# Altium schematic sheet SCH007 - SFP_10GbE.SchDoc
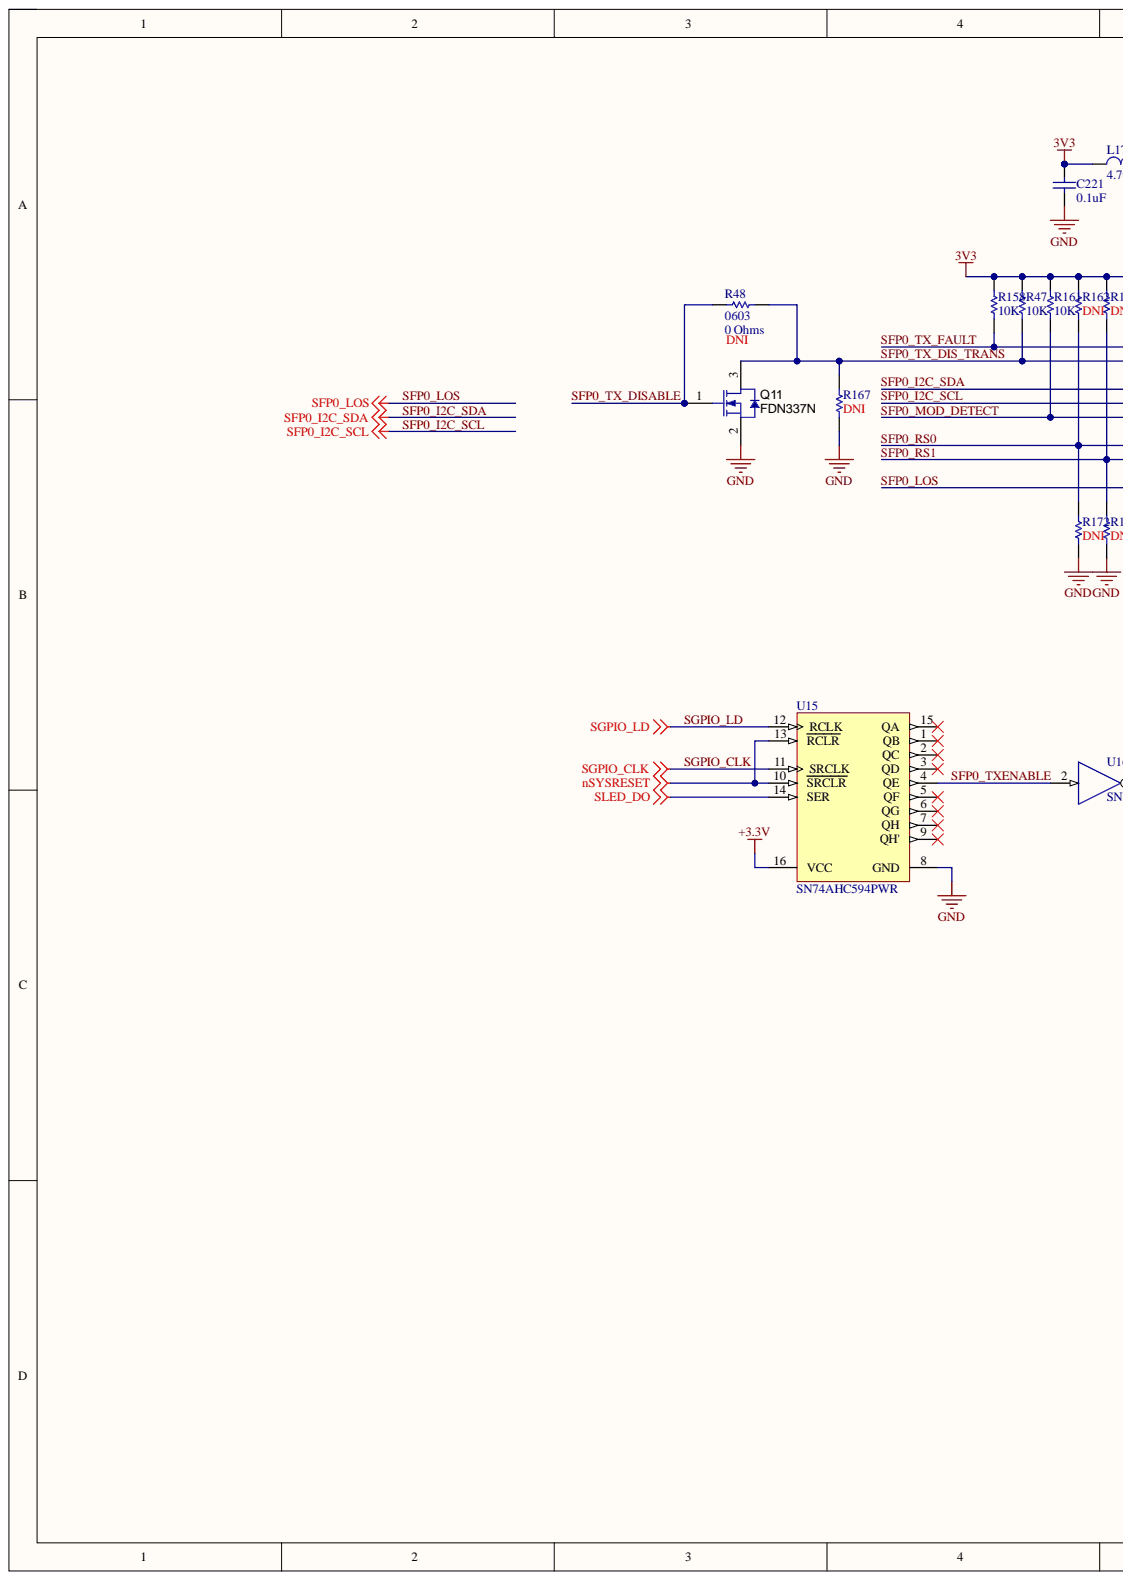
[diagram p0: SCH007 - SFP_10GbE.SchDoc, left]
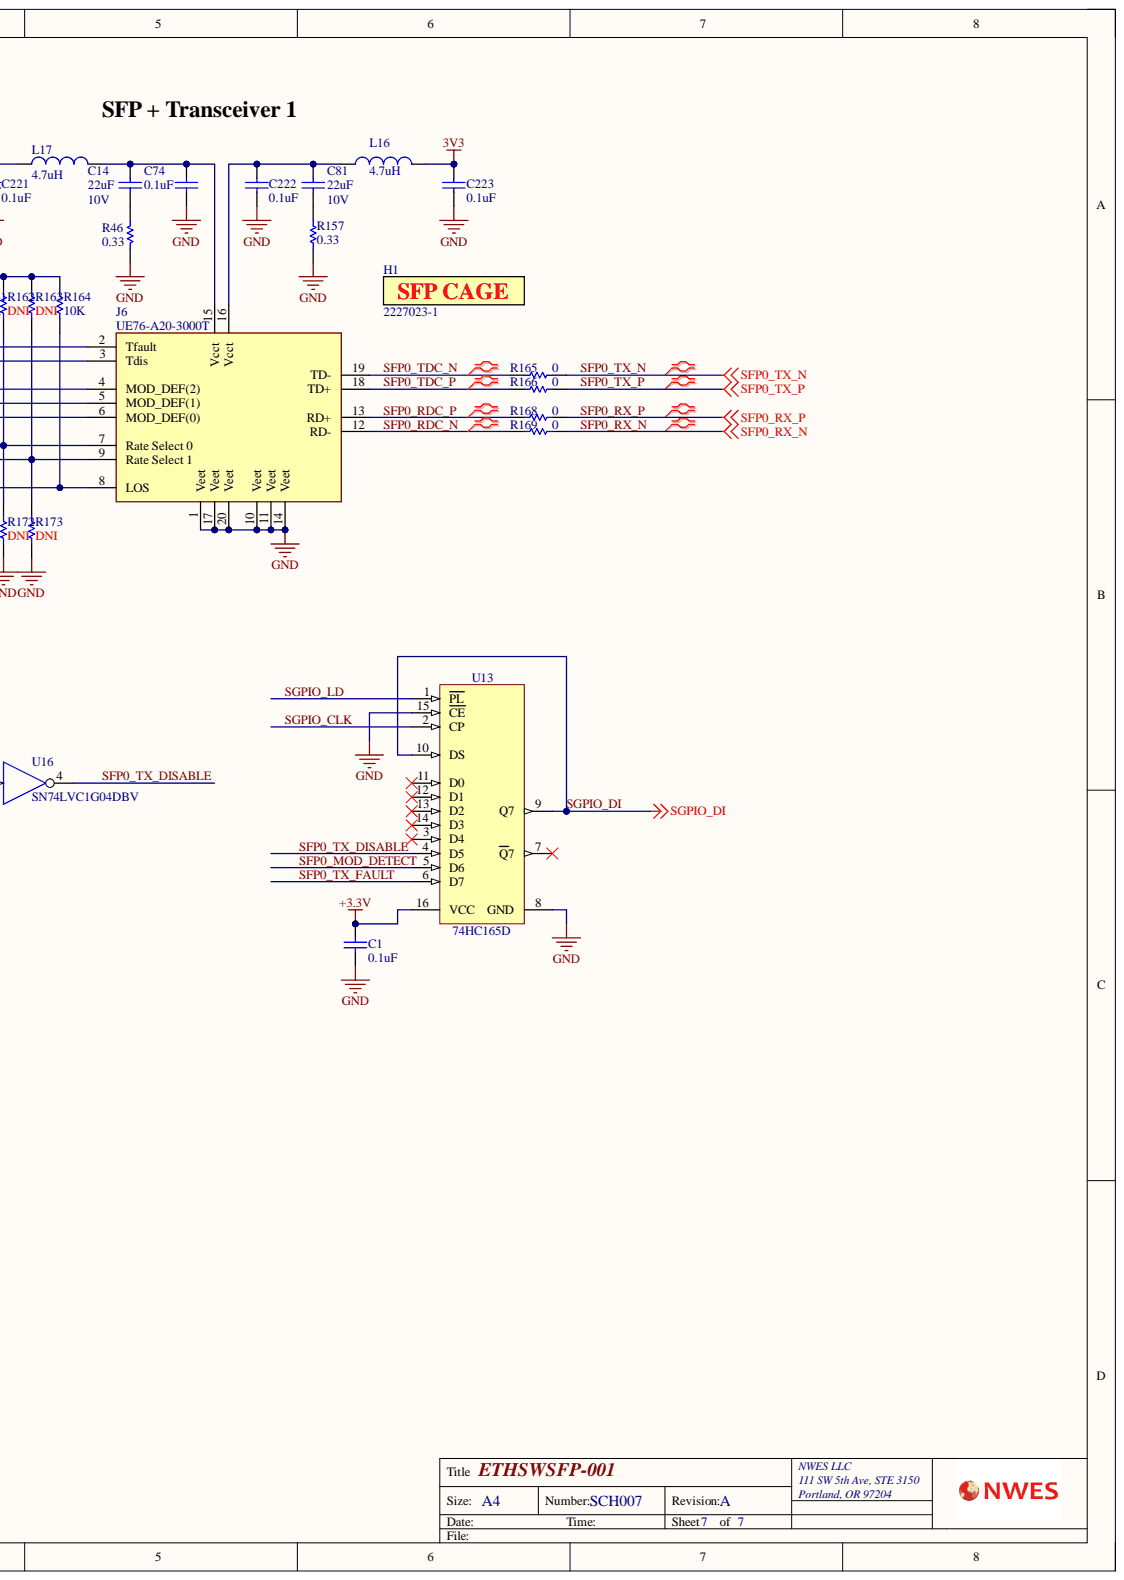
[diagram p1: SCH007 - SFP_10GbE.SchDoc, right]

## Parts
C1  0.1uF  [CAPC1005X55USR]
C14  22uF  Voltage Rating: 10V  [CAP_0603]
C74  0.1uF  [CAPC1005X55USR]
C81  22uF  Voltage Rating: 10V  [CAP_0603]
C221  0.1uF  [CAPC1005X55USR]
C222  0.1uF  [CAPC1005X55USR]
C223  0.1uF  [CAPC1005X55USR]
H1  2227023-1  Connector Type: SFP  [TE_2227023-1]
J6  UE76-A20-3000T  [AMPHENOL_UE76-A20-3000T]
L16  4.7uH  [INDC2520X120N]
L17  4.7uH  [INDC2520X120N]
Q11  FDN337N  [SOT-23]
R46  0.33  [RESC1608N]
R47  10K  [RESC1005X40USR]
R48  DNI  value 0 Ohms  Case Code (Imperial): 0603  [RESC1608_IS0603N]
R157  0.33  [RESC1608N]
R158  10K  [RESC1005X40USR]
R161  10K  [RESC1005X40USR]
R162  DNI  value 10K  [RESC1005X40USR]
R163  DNI  [RESC1005X40USR]
R164  10K  [RESC1005X40USR]
R165  0  [RESC1005X40USR]
R166  0  [RESC1005X40USR]
R167  DNI  [RESC1005X40USR]
R168  0  [RESC1005X40USR]
R169  0  [RESC1005X40USR]
R172  DNI  [RESC1005X40USR]  (no BOM)
R173  DNI  [RESC1005X40USR]  (no BOM)
U13  74HC165D  [SOIC127P600X175-16N]
U15  SN74AHC594PWR  [PW0016A_M]
U16  SN74LVC1G04DBV  [SOT95P280X145-5N]

## Nets
3V3: C221.2 C223.2 L16.2 L17.2 R47.2 R158.2 R161.2 R162.2 R163.2 R164.2
+3.3V: C1.2 U13.16(VCC) U15.16(VCC)
GND: C1.1 C74.1 C221.1 C222.1 C223.1 J6.1(Veet) J6.10(Veet) J6.11(Veet) J6.14(Veet) J6.17(Veet) J6.20(Veet) Q11.2 R46.1 R157.1 R167.1 R172.1 R173.1 U13.8(GND) U13.15(CE) U15.8(GND) U16.3
nSYSRESET: U15.10(SRCLR) U15.13(RCLR)
SLED_DO: U15.14(SER)
VDD: U16.5
SFP0_I2C_SCL: J6.5(MOD_DEF(1))
SFP0_I2C_SDA: J6.4(MOD_DEF(2))
SFP0_LOS: J6.8(LOS) R164.1
SFP0_MOD_DETECT: J6.6(MOD_DEF(0)) R161.1 U13.5(D6)
SFP0_RDC_N: J6.12(RD-) R169.1
SFP0_RDC_P: J6.13(RD+) R168.1
SFP0_RS0: J6.7(Rate_Select_0) R162.1 R172.2
SFP0_RS1: J6.9(Rate_Select_1) R163.1 R173.2
SFP0_RX_N: R169.2
SFP0_RX_P: R168.2
SFP0_TDC_N: J6.19(TD-) R165.1
SFP0_TDC_P: J6.18(TD+) R166.1
SFP0_TX_DIS_TRANS: J6.3(Tdis) Q11.3 R47.1 R48.2 R167.2
SFP0_TX_DISABLE: Q11.1 R48.1 U13.4(D5) U16.4
SFP0_TX_FAULT: J6.2(Tfault) R158.1 U13.6(D7)
SFP0_TX_N: R165.2
SFP0_TX_P: R166.2
SFP0_TXENABLE: U15.4(QE) U16.2
SGPIO_CLK: U13.2(CP) U15.11(SRCLK)
SGPIO_DI: U13.9(Q7) U13.10(DS)
SGPIO_LD: U13.1(PL) U15.12(RCLK)
NetC14_1: C14.1 C74.2 J6.15(Vcct) L17.1
NetC14_2: C14.2 R46.2
NetC81_1: C81.1 C222.2 J6.16(Vcct) L16.1
NetC81_2: C81.2 R157.2

Not connected: U13 3(D4) 7(Q7) 11(D0) 12(D1) 13(D2) 14(D3); U15 1(QB) 2(QC) 3(QD) 5(QF) 6(QG) 7(QH) 9(QH') 15(QA)

## Library data of the parts
C1 C74 C221-C223 = CAP CER 0.1UF 25V X5R 0402: Manufacturer: Samsung Electro-Mechanics; Manufacturer Part Number: CL05A104KA5NNNC; Component Kind: Standard; Description: CAP CER 0.1UF 25V X5R 0402; Height: 0.55mm; Package: 0402; Supplier 1: Digi-Key; Supplier Part Number 1: 1276-1043-1-ND; value: 0.1uF; Library Name: Radar FPGA RF.SCHLIB
C14 C81 = CAP CER 22UF 10V X5R 0603: Multilayer Ceramic Capacitor, 22 uF, 10 V, ± 20%, X5R, 0603 [1608 Metric]; Manufacturer: TDK; Manufacturer Part Number: C1608X5R1A226M080AC; Capacitance: 22uF; Case Code (Imperial): 0603; Case Code (Metric): 1608; Case/Package: 0603; Category: Ceramic Capacitors; Component Kind: Standard; ComponentLink1Description: https://datasheet.ciiva.com/6274/tdkmlcccaprange-6274860.pdf?src-supplier=Digi-Key; ComponentLink1URL: https://datasheet.ciiva.com/6274/tdkmlcccaprange-6274860.pdf?src-supplier=Digi-Key; ComponentLink2Description: https://datasheet.ciiva.com/6274/tdkmlcccaprange-6274859.pdf?src-supplier=Digi-Key; ComponentLink2URL: https://datasheet.ciiva.com/6274/tdkmlcccaprange-6274859.pdf?src-supplier=Digi-Key; ComponentLink3Description: https://datasheet.ciiva.com/6274/tdkmlcccaprange-6274861.pdf?src-supplier=Digi-Key; ComponentLink3URL: https://datasheet.ciiva.com/6274/tdkmlcccaprange-6274861.pdf?src-supplier=Digi-Key; ComponentLink4Description: https://datasheet.ciiva.com/24216/mlcc-commercial-general-en-24216575.pdf?src-supplier=Mouser; ComponentLink4URL: https://datasheet.ciiva.com/24216/mlcc-commercial-general-en-24216575.pdf?src-supplier=Mouser; Depth: 0.8mm; Dielectric: X5R; Dielectric Material: Ceramic; Height: 0.8mm; Lead Free: Lead Free; Length: 1.6mm; Max Operating Temperature: 85°C; Min Operating Temperature: -55°C; Mount: Surface Mount; Package Quantity: 4000; Packaging: Tape and Reel; Pricing 1: 1=0.45000, 10=0.31300, 50=0.21920, 100=0.18790, 500=0.14090, 1000=0.11898 (USD); Reach SVHC Compliant: Yes; Resistor Type: Ceramic; RoHS: Yes; RoHS Compliant: Yes; Series: C; Stock 1: 4100481; Supplier 1: Digi-Key; Supplier Part Number 1: 445-9077-1-ND; Termination: SMD/SMT; Thickness: 0.035inch; Tolerance: 20%; Voltage Rating (DC): 10V; Weight: 7.1E-05oz; Width: 0.8mm; Library Name: Radar FPGA RF.SCHLIB
H1 = TE_2227023-1: Connector Accessories 1 Port SFP Cage Press Fit Right Angle Through Hole Nickel Tray; Manufacturer: TE Connectivity AMP; Data Rate: 4Gbps; Number of Ports: 1; PCB Thickness: 0.059inch; Tail Length: 3mm; Category: Connectors; Component Kind: Standard; ComponentLink1Description: https://datasheet.ciiva.com/45236/eng-cd-2227023-1-45236427.pdf?src-supplier=Avnet; ComponentLink1URL: https://datasheet.ciiva.com/45236/eng-cd-2227023-1-45236427.pdf?src-supplier=Avnet; ELV: Compliant; Features: Shielded; Library Name: XEM8320.SchLib; Manufacturer Part Number: 2227023-1; Mounting Technology: Through Hole; Number of Positions: 1; Packaging: Tray; Pricing: 1=2.23000, 24=1.98875, 48=1.97854, 96=1.95823, 240=1.79675, 456=1.78667, 960=1.62516, 2400=1.45356 (USD); RoHS: Yes; RoHS Compliant: Yes; Series: -; Stock: 3865; Supplier 1: Digi-Key; Supplier Part Number 1: A120615-ND; Termination: Press-Fit
J6 = UE76-A20-3000T: Conn SFP+ RCP 20 POS 0.8mm Solder RA SMD 20 Terminal 1 Port T/R; Manufacturer: Amphenol Communications Solutions; Component Kind: Standard; Manufacturer Part Number: UE76-A20-3000T; Pricing 1: 500=0.81500, 1000=0.79500, 1500=0.78000, 2000=0.77500, 2500=0.75000 (USD); RoHS: No; Stock 1: 500; Supplier 1: Future Electronics; Supplier Part Number 1: 9135124; Library Name: Radar FPGA RF.SCHLIB
L16 L17 = FIXED IND 4.7UH 2A 200 MOHM SMD: Manufacturer: Murata; Manufacturer Part Number: DFE252012P-4R7M=P2; Core Material: Ferrite; DC Resistance (DCR): 200mR; Max DC Current: 1.4A; Shielding: Shielded; Test Frequency: 1MHz; Tolerance: 20%; Case Code (Imperial): 1008; Case Code (Metric): 2520; Case/Package: 1008; Category: Fixed Inductors; Component Kind: Standard; ComponentLink1Description: http://www.farnell.com/datasheets/2550138.pdf?src-supplier=Farnell; ComponentLink1URL: http://www.farnell.com/datasheets/2550138.pdf?src-supplier=Farnell; ComponentLink2Description: http://www.toko.co.jp/products/pdf/inductors/dfe252012p.pdf?src-supplier=Avnet; ComponentLink2URL: http://www.toko.co.jp/products/pdf/inductors/dfe252012p.pdf?src-supplier=Avnet; ComponentLink3Description: http://www.farnell.com/datasheets/2550138.pdf?src-supplier=Newark; ComponentLink3URL: http://www.farnell.com/datasheets/2550138.pdf?src-supplier=Newark; ComponentLink4Description: http://www.murata.com/~/media/webrenewal/products/inductor/chip/tokoproducts/wirewoundmetalalloychiptype/m_dfe252012p.ashx?src-supplier=Digi-Key; ComponentLink4URL: http://www.murata.com/~/media/webrenewal/products/inductor/chip/tokoproducts/wirewoundmetalalloychiptype/m_dfe252012p.ashx?src-supplier=Digi-Key; ComponentLink5Description: https://www.murata.com/~/media/webrenewal/products/inductor/chip/tokoproducts/wirewoundmetalalloychiptype/m_dfe252012p.ashx?src-supplier=Digi-Key; ComponentLink5URL: https://www.murata.com/~/media/webrenewal/products/inductor/chip/tokoproducts/wirewoundmetalalloychiptype/m_dfe252012p.ashx?src-supplier=Digi-Key; Current Rating: 2A; Depth: 2mm; Description: FIXED IND 4.7UH 2A 200 MOHM SMD; Height - Seated (Max): 0.047inch; Inductance: 4.7uH; Length: 0.098inch; Max Operating Temperature: 125°C; Min Operating Temperature: -40°C; Mount: Surface Mount; Packaging: Tape and Reel; Pricing 1: 1=0.31000, 10=0.27500, 25=0.24040, 50=0.20600, 100=0.18890, 250=0.17168, 500=0.16140, 1000=0.12362 (USD); Resistor Type: Wirewound,Metal Alloy; RoHS: Yes; RoHS Compliant: Yes; Stock 1: 151905; Supplier 1: Digi-Key; Supplier Part Number 1: 490-10651-1-ND; Width: 0.079inch; Library Name: Radar FPGA RF.SCHLIB
Q11 = MOSFET N-CH 30V 2.2A SUPERSOT3: MOSFET N-CH 30V 2.2A SSOT3; Manufacturer: ON Semiconductor / Fairchild; Drain to Source Resistance: 65mR; Element Configuration: Single; Fall Time: 10ns; Gate to Source Voltage (Vgs): 8V; Input Capacitance: 300pF; Max Power Dissipation: 500mW; Nominal Vgs: 700mV; Number of Elements: 1; Polarity: N-CHANNEL; Turn-Off Delay Time: 17ns; Turn-On Delay Time: 4ns; Case/Package: TO-236-3; Category: MOSFETs; Component Kind: Standard; ComponentLink1Description: https://datasheet.ciiva.com/14092/fdn337n-eng-datasheet-14092051.pdf?src-supplier=Distrelec; ComponentLink1URL: https://datasheet.ciiva.com/14092/fdn337n-eng-datasheet-14092051.pdf?src-supplier=Distrelec; ComponentLink2Description: https://datasheet.ciiva.com/4442/fdn337n-44286-4442315.pdf?src-supplier=Mouser; ComponentLink2URL: https://datasheet.ciiva.com/4442/fdn337n-44286-4442315.pdf?src-supplier=Mouser; ComponentLink3Description: https://datasheet.ciiva.com/19470/871017-19470804.pdf?src-supplier=Jameco; ComponentLink3URL: https://datasheet.ciiva.com/19470/871017-19470804.pdf?src-supplier=Jameco; ComponentLink4Description: https://datasheet.ciiva.com/6037/fdn337n-6037720.pdf?src-supplier=Farnell; ComponentLink4URL: https://datasheet.ciiva.com/6037/fdn337n-6037720.pdf?src-supplier=Farnell; ComponentLink5Description: https://datasheet.ciiva.com/22681/220571-22681884.pdf?src-supplier=Element14; ComponentLink5URL: https://datasheet.ciiva.com/22681/220571-22681884.pdf?src-supplier=Element14; ComponentLink6Description: https://datasheet.ciiva.com/22655/220571-22655333.pdf?src-supplier=Element14; ComponentLink6URL: https://datasheet.ciiva.com/22655/220571-22655333.pdf?src-supplier=Element14; ComponentLink7Description: https://datasheet.ciiva.com/7968/220571-7968126.pdf?src-supplier=Element14; ComponentLink7URL: https://datasheet.ciiva.com/7968/220571-7968126.pdf?src-supplier=Element14; ComponentLink8Description: https://datasheet.ciiva.com/8115/fdn337n-8115069.pdf?src-supplier=Avnet; ComponentLink8URL: https://datasheet.ciiva.com/8115/fdn337n-8115069.pdf?src-supplier=Avnet; ComponentLink9Description: https://datasheet.ciiva.com/16931/fdn337n-16931214.pdf?src-supplier=Future+Electronics; ComponentLink9URL: https://datasheet.ciiva.com/16931/fdn337n-16931214.pdf?src-supplier=Future+Electronics; ComponentLink10Description: https://datasheet.ciiva.com/8115/fdn337n-8115063.pdf?src-supplier=Avnet; ComponentLink10URL: https://datasheet.ciiva.com/8115/fdn337n-8115063.pdf?src-supplier=Avnet; ComponentLink11Description: https://datasheet.ciiva.com/931/fdn337n-931876.pdf?src-supplier=Digi-Key; ComponentLink11URL: https://datasheet.ciiva.com/931/fdn337n-931876.pdf?src-supplier=Digi-Key; ComponentLink12Description: https://datasheet.ciiva.com/931/fdn337n-931877.pdf?src-supplier=Digi-Key; ComponentLink12URL: https://datasheet.ciiva.com/931/fdn337n-931877.pdf?src-supplier=Digi-Key; ComponentLink13Description: https://datasheet.ciiva.com/931/fdn337n-931875.pdf?src-supplier=Digi-Key; ComponentLink13URL: https://datasheet.ciiva.com/931/fdn337n-931875.pdf?src-supplier=Digi-Key; Contact Plating: Tin,Matte; Continuous Drain Current (ID): 2.2A; Current Rating: 2.2A; Drain to Source Breakdown Voltage: 30V; Drain to Source Voltage (Vdss): 30V; Drain-source On Resistance-Max: 65mR; Dual Supply Voltage: 30V; Height: 0.94mm; Lead Free: Lead Free; Length: 2.92mm; Manufacturer Part Number: FDN337N; Max Operating Temperature: 150°C; Min Operating Temperature: -55°C; Mount: Surface Mount; Number of Pins: 3; On-State Resistance: 65mR; Package Quantity: 3000; Packaging: Tape and Reel; Power Dissipation: 500mW; Pricing 1: 1=0.49000, 10=0.42000, 100=0.31350, 500=0.24636, 1000=0.19037 (USD); Rds On Max: 65mR; Reach SVHC Compliant: Yes; Rise Time: 10ns; RoHS: Yes; RoHS Compliant: Yes; Series: -; Stock 1: 48367; Supplier 1: Digi-Key; Supplier Part Number 1: FDN337NCT-ND; Termination: SMD/SMT; Threshold Voltage: 700mV; Voltage Rating (DC): 30V; Weight: 0.03g; Width: 3.05mm; Library Name: Radar FPGA RF.SCHLIB
R46 R157 = RES 0.33 OHM 1% 1/10W 0603: Manufacturer: Panasonic Electronic Components; Manufacturer Part Number: ERJ-3RQFR33V; Component Kind: Standard; Description: RES 0.33 OHM 1% 1/10W 0603; Height: 0.4mm; Package: 0402; Supplier 1: Digi-Key; Supplier Part Number 1: P.33AJCT-ND; value: 0.33; Library Name: Radar FPGA RF.SCHLIB
R47 R158 R161-R164 = RES 10K OHM 1% 1/16W 0402: Manufacturer: YAGEO; Manufacturer Part Number: RC0402FR-0710KL; Component Kind: Standard; Description: RES 10K OHM 1% 1/16W 0402; Height: 0.4mm; Package: 0402; Supplier 1: Digi-Key; Supplier Part Number 1: 311-10.0KLRCT-ND; Tolerance: ±1%; Library Name: Radar FPGA RF.SCHLIB
  R47 R158 R161 R163 R164: value: 10K
R48 = 1058: Resistor - 0 Ohms Jumper 0603; Manufacturer: Bourns; Manufacturer #: CR0603-J/-000ELF; Max Power Dissipation: 100mW; Power: None; Resistance: 0mR; Temperature Coefficient: 500ppm/°C; Voltage Rating: 50V; Case Code (Metric): 1608; Case/Package: 0603; Component Kind: Standard; Composition: Thick Film; Contact Plating: Tin; Depth: 0.8mm; Height: 0.45mm; Length: 1.6mm; Library Name: Master.SCHLIB; Manufacturer Part Number: CR0603-J/-000ELF; Max Operating Temperature: 155°C; Military Standard: Not; Min Operating Temperature: -55°C; Mount: Surface Mount; Number of Pins: 2; Package Quantity: 5000; Packaging: Tape and Reel; Pacstar PN: 1058; Power Rating: 100mW; Pricing 1: 5000=0.003, 10000=0.002, 20000=0.001, 30000=0.001, 50000=0.001 (USD); Radiation Hardening: No; REACH SVHC: No SVHC; Status: Gold; Stock 1: 25000; Stock 2: 0; Supplier 1: Avnet; Supplier 2: Digi-Key; Supplier 3: Mouser; Supplier 4: Allied Electronics; Supplier Part Number 1: CR0603-J/-000ELF; Supplier Part Number 2: CR0603-J/-000ELFTR-ND; Supplier Part Number 3: 652-CR0603-J/-000ELF; Supplier Part Number 4: 70154688; Technology: SMT; Termination: SMD/SMT; Thickness: 457um; Tolerance: None; Type: Resistor; Value1: 0 Ohms; Voltage Rating (DC): 50V; Width: 0.8mm; Checked By: JAK
R165 R166 R168 R169 = RES 0 OHM JUMPER 1/16W 0402: Manufacturer: Yageo; Manufacturer Part Number: RC0402JR-070RL; Component Kind: Standard; Description: RES 0 OHM JUMPER 1/16W 0402; Height: 0.4mm; Package: 0402; Supplier 1: Digi-Key; Supplier Part Number 1: 311-0.0JRTR-ND; value: 0; Library Name: Radar FPGA RF.SCHLIB
R167 = RES 0 OHM JUMPER 1/16W 0402: Manufacturer: Yageo; Manufacturer Part Number: RC0402JR-070RL; value: 0; Component Kind: Standard; Description: RES 0 OHM JUMPER 1/16W 0402; Height: 0.4mm; Package: 0402; Supplier 1: Digi-Key; Supplier Part Number 1: 311-0.0JRTR-ND; Library Name: Radar FPGA RF.SCHLIB
R172 R173 = RES 10K OHM 1% 1/16W 0402: RES 0 OHM JUMPER 1/16W 0402; Manufacturer: YAGEO; Manufacturer Part Number: RC0402FR-070RL; Tolerance: ±1%; value: 0R; Height: 0.4mm; Package: 0402; Supplier 1: Digi-Key; Supplier Part Number 1: 311-0.0LRTR-ND; Library Name: Radar FPGA RF.SCHLIB
U13 = 74HC165D: 8-Bit Parallel-In/Serial Out Shift Register; Manufacturer: NXP SEMICONDUCTORS; Bonding: No; Database Table Name: Standard Logic; PressFit: No; Case: SOIC16; Component Kind: Standard; Component Type: Standard; ComponentHeight: 1.75mm; Device: 74HC165D; Library Name: ICs And Semiconductors.DbLib; Manufacturer Part Number: 74HC165D; Mounted: Yes; PackageDescription: SOIC 16, Pitch 1.27mm - Body 4x10mm, IPC Medium Density; Part Description: 8-Bit Parallel-In/Serial Out Shift Register; Part Number: 74HC165D; Pin Count: 16; Sense: No; SMD: Yes; Socket: No; Author: -
U15 = CMP-0807-00197-3: 8-Bit Shift Registers With Output Registers, PW0016A, LARGE T&R; Manufacturer: Texas Instruments; 3-State Output: No; Bits: 8; F @ Nom Voltage(Max)(Mhz): 110; ICC @ Nom Voltage(Max)(mA): 0.04; Input Type: CMOS; Output Drive (IOL/IOH)(Max)(mA): 50/-50; Rating: Catalog; Technology Family: AHC; tpd @ Nom Voltage(Max)(ns): 13.9, 10.1; VCC(Max)(V): 5.5; VCC(Min)(V): 2; Voltage(Nom)(V): 3.3, 5; Code_JEDEC: MO-153; Component Kind: Standard; DatasheetVersion: SCLS423G; Generic Part Number: SN74AHC594; Library Name: Altium Content Vault; Operating Temperature Range(C): -40 to 125; Output Type: CMOS; PackageReference: PW0016A; PackageVersion: http://www.ti.com/lit/ml/mpds361/mpds361.pdf
U16 = SN74LVC1G04DBV: Single Inverter Buffer; Manufacturer: TEXAS INSTRUMENTS; Part Description: Single Inverter Gate; Bonding: No; Database Table Name: Standard Logic; PressFit: No; Case: SOT23-5; Component Kind: Standard; Component Type: Standard; ComponentHeight: 1.45mm; Device: SN74LVC1G04DBV; Library Name: ICs And Semiconductors.DbLib; Manufacturer Part Number: SN74LVC1G04DBV; Mounted: Yes; PackageDescription: SOT, 0.95mm Pitch; 5 Pin, 1.60mm W X 2.90mm L X 1.45mm H body, IPC Medium Density; Part Number: SN74LVC1G04DBV; Pin Count: 5; Sense: No; SMD: Yes; Socket: No; Author: -
